# Altium schematic sheet oled-display-v3.2.SchDoc(oled-display-v3.2)
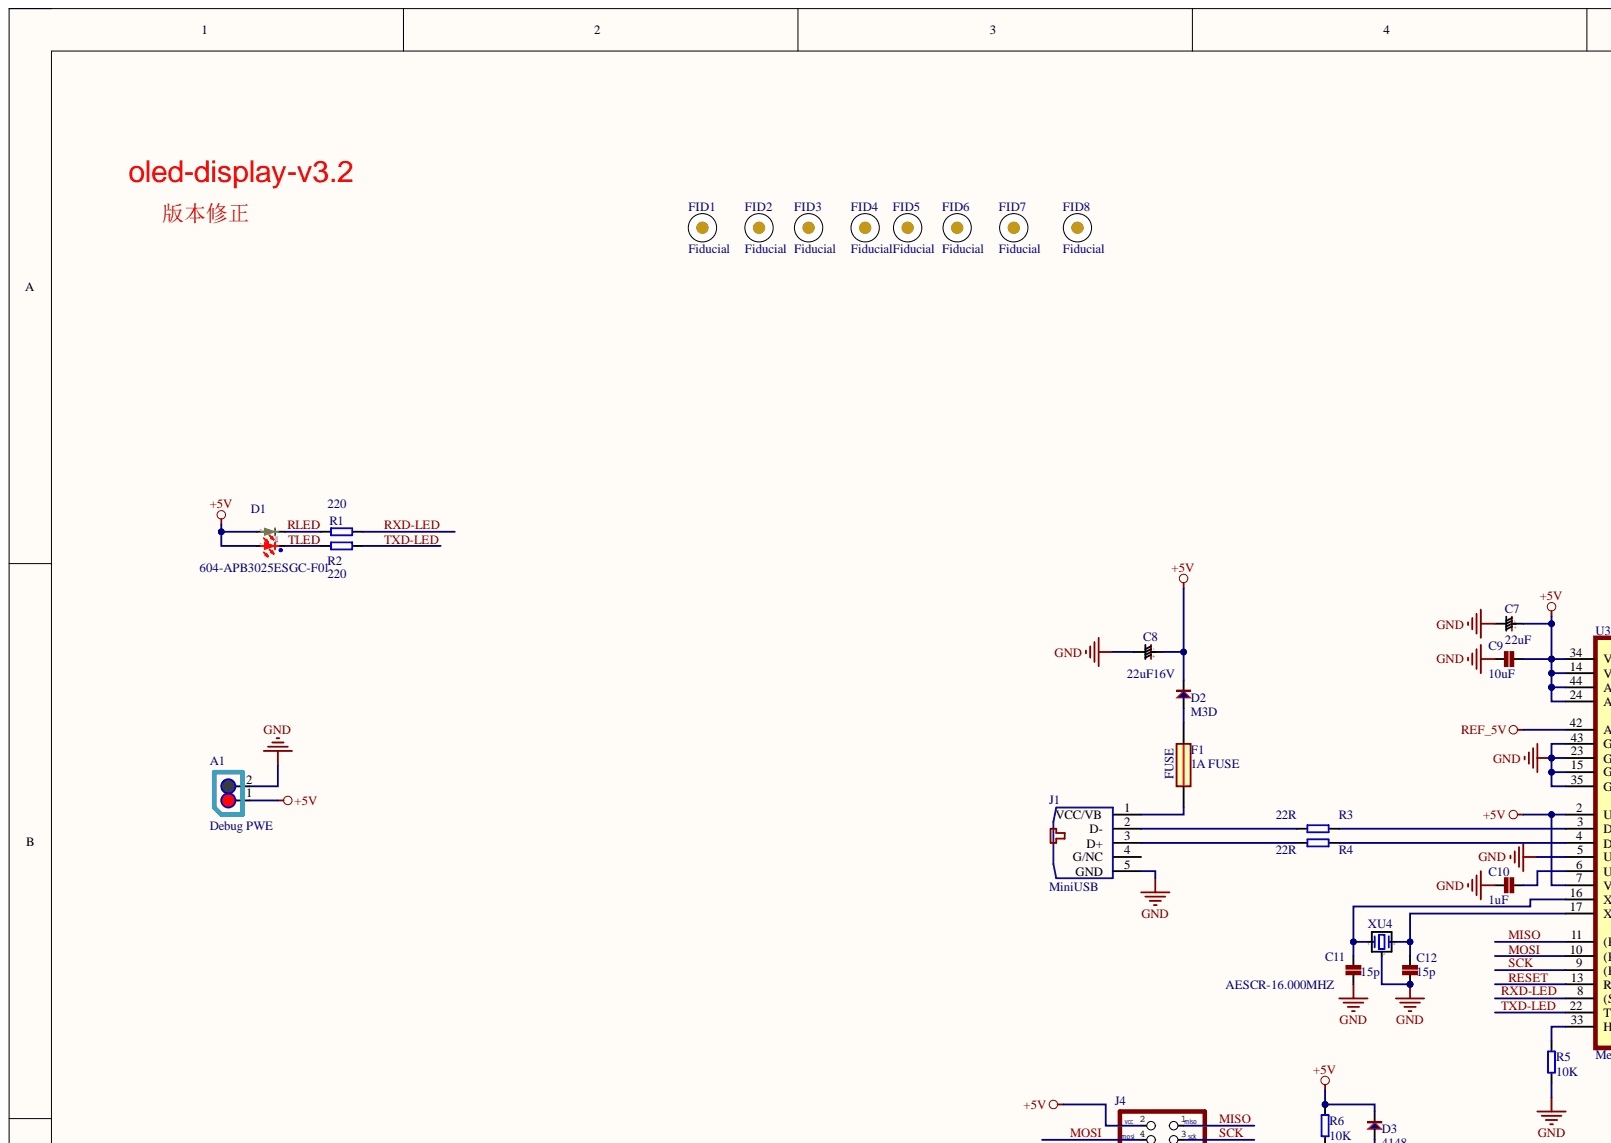
[diagram p0: oled-display-v3.2.SchDoc, top left]
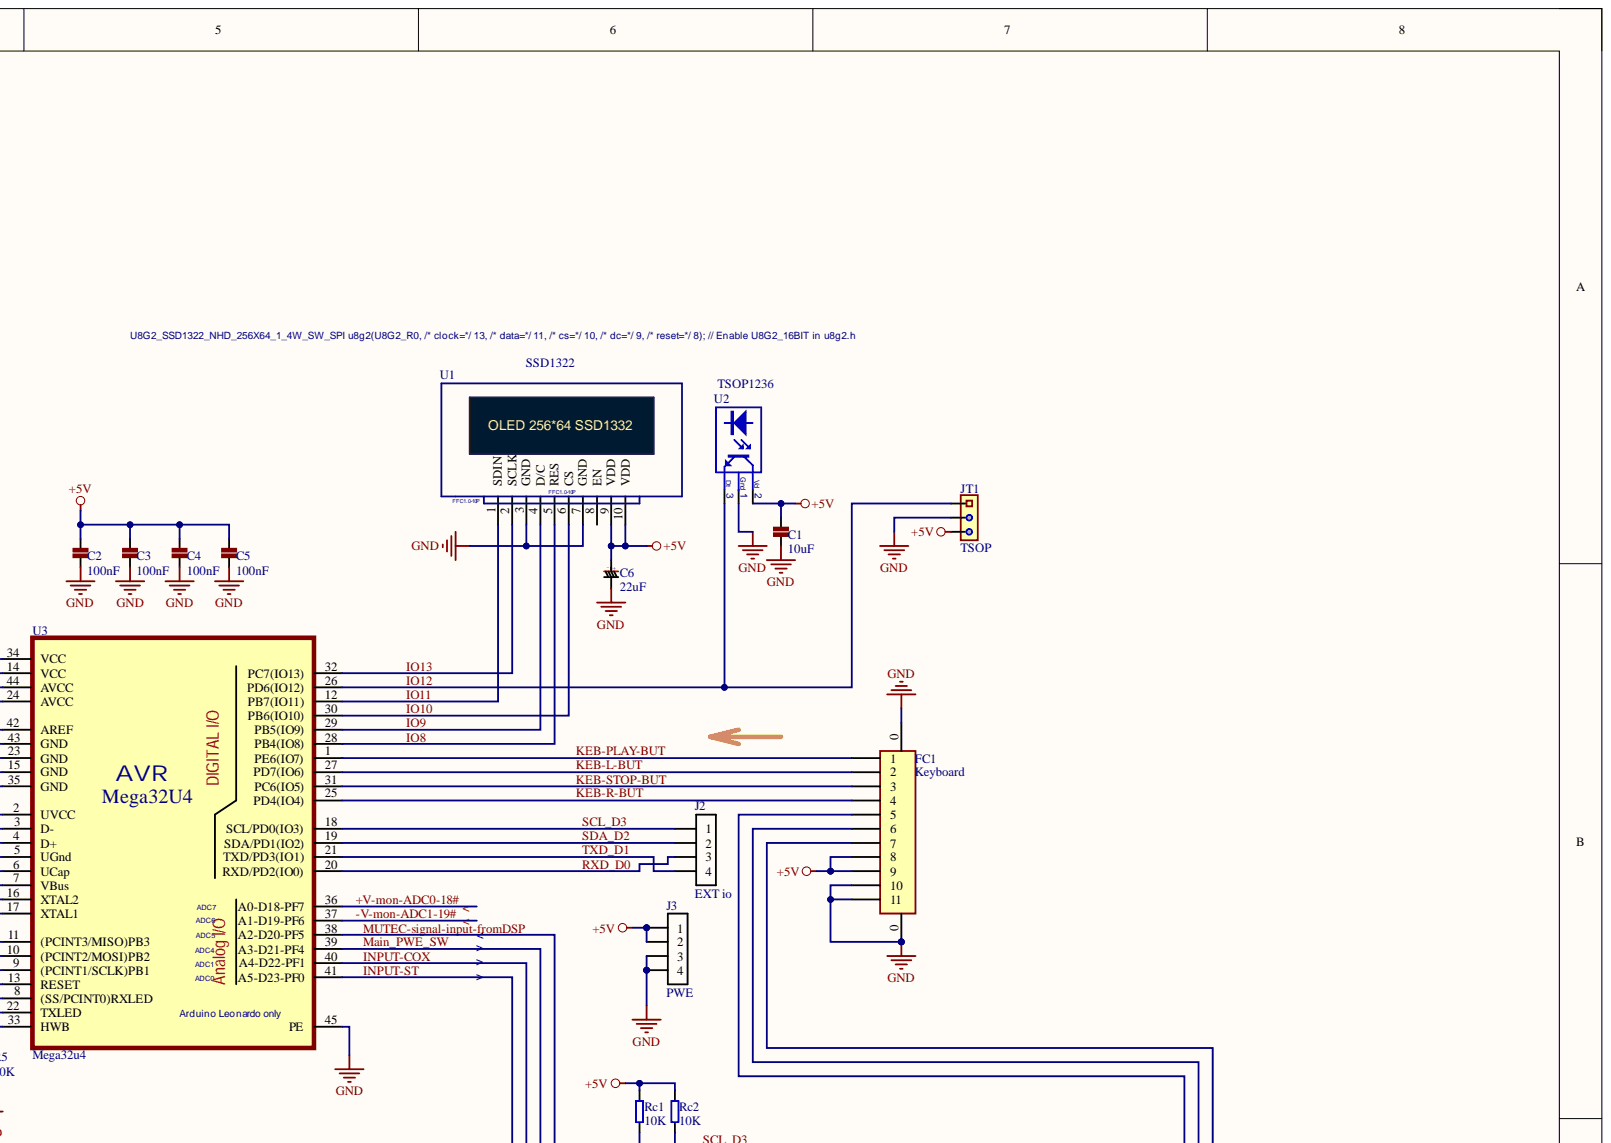
[diagram p1: oled-display-v3.2.SchDoc, top right]
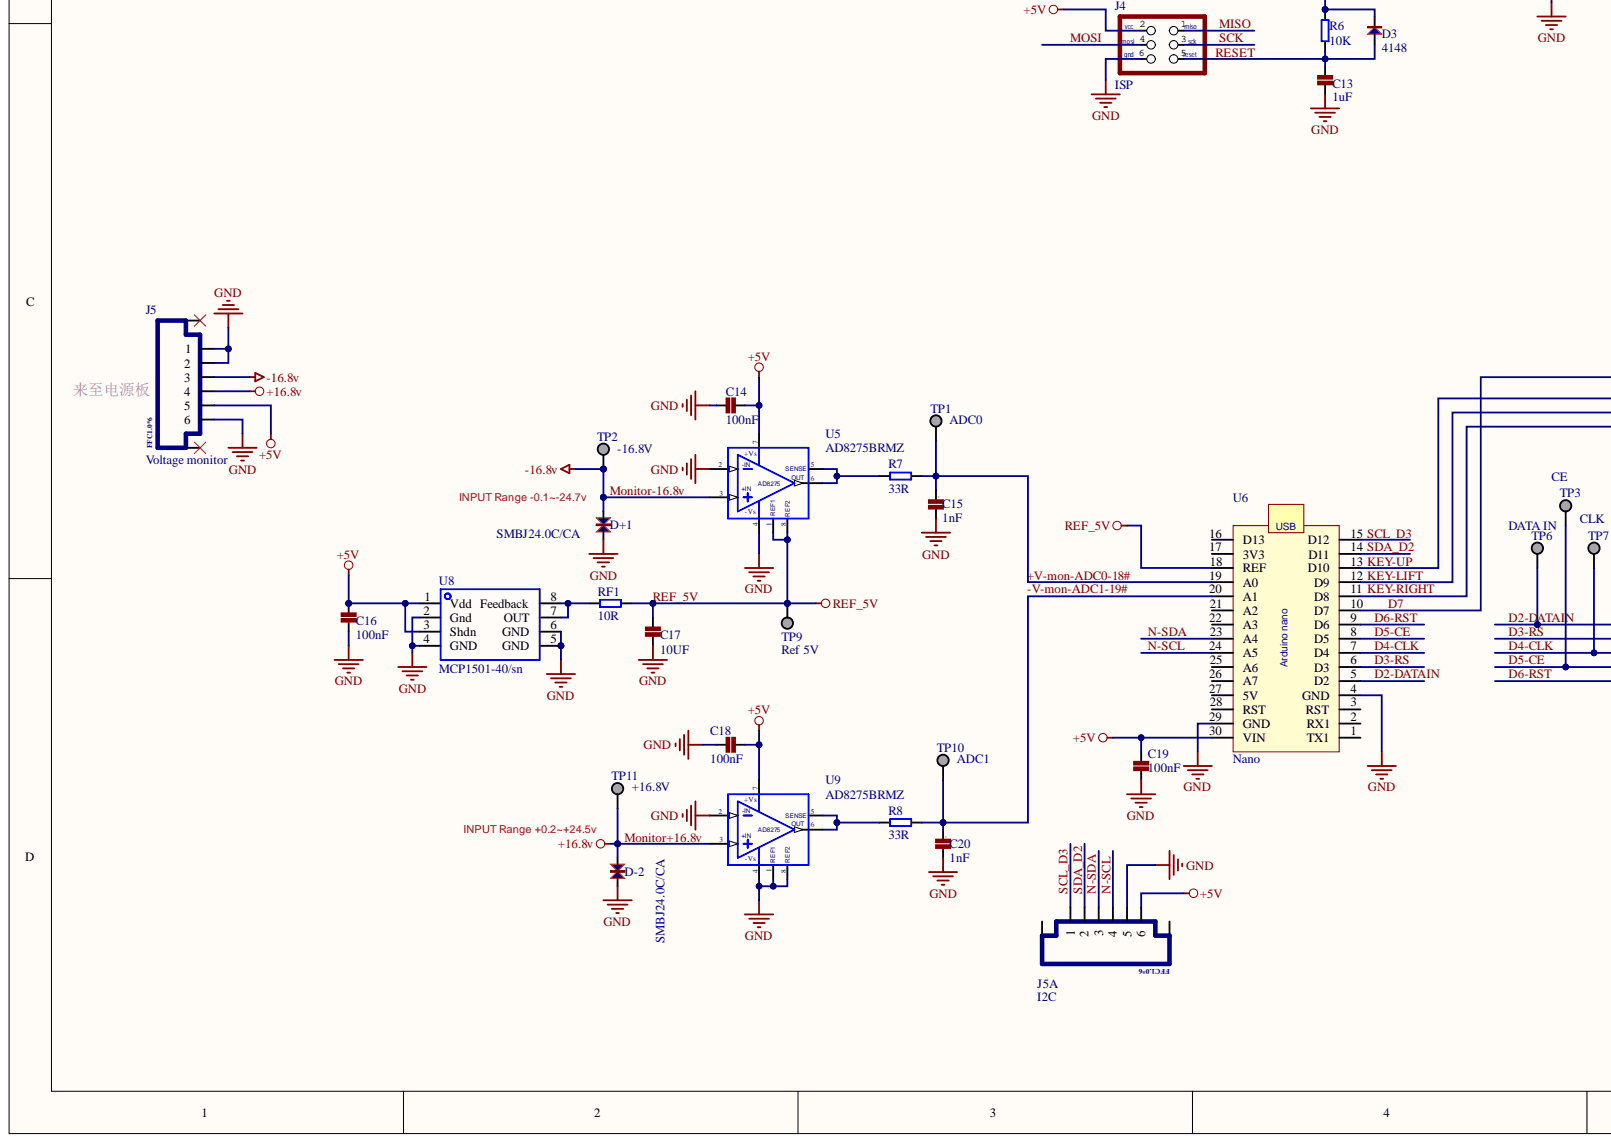
[diagram p2: oled-display-v3.2.SchDoc, bottom left]
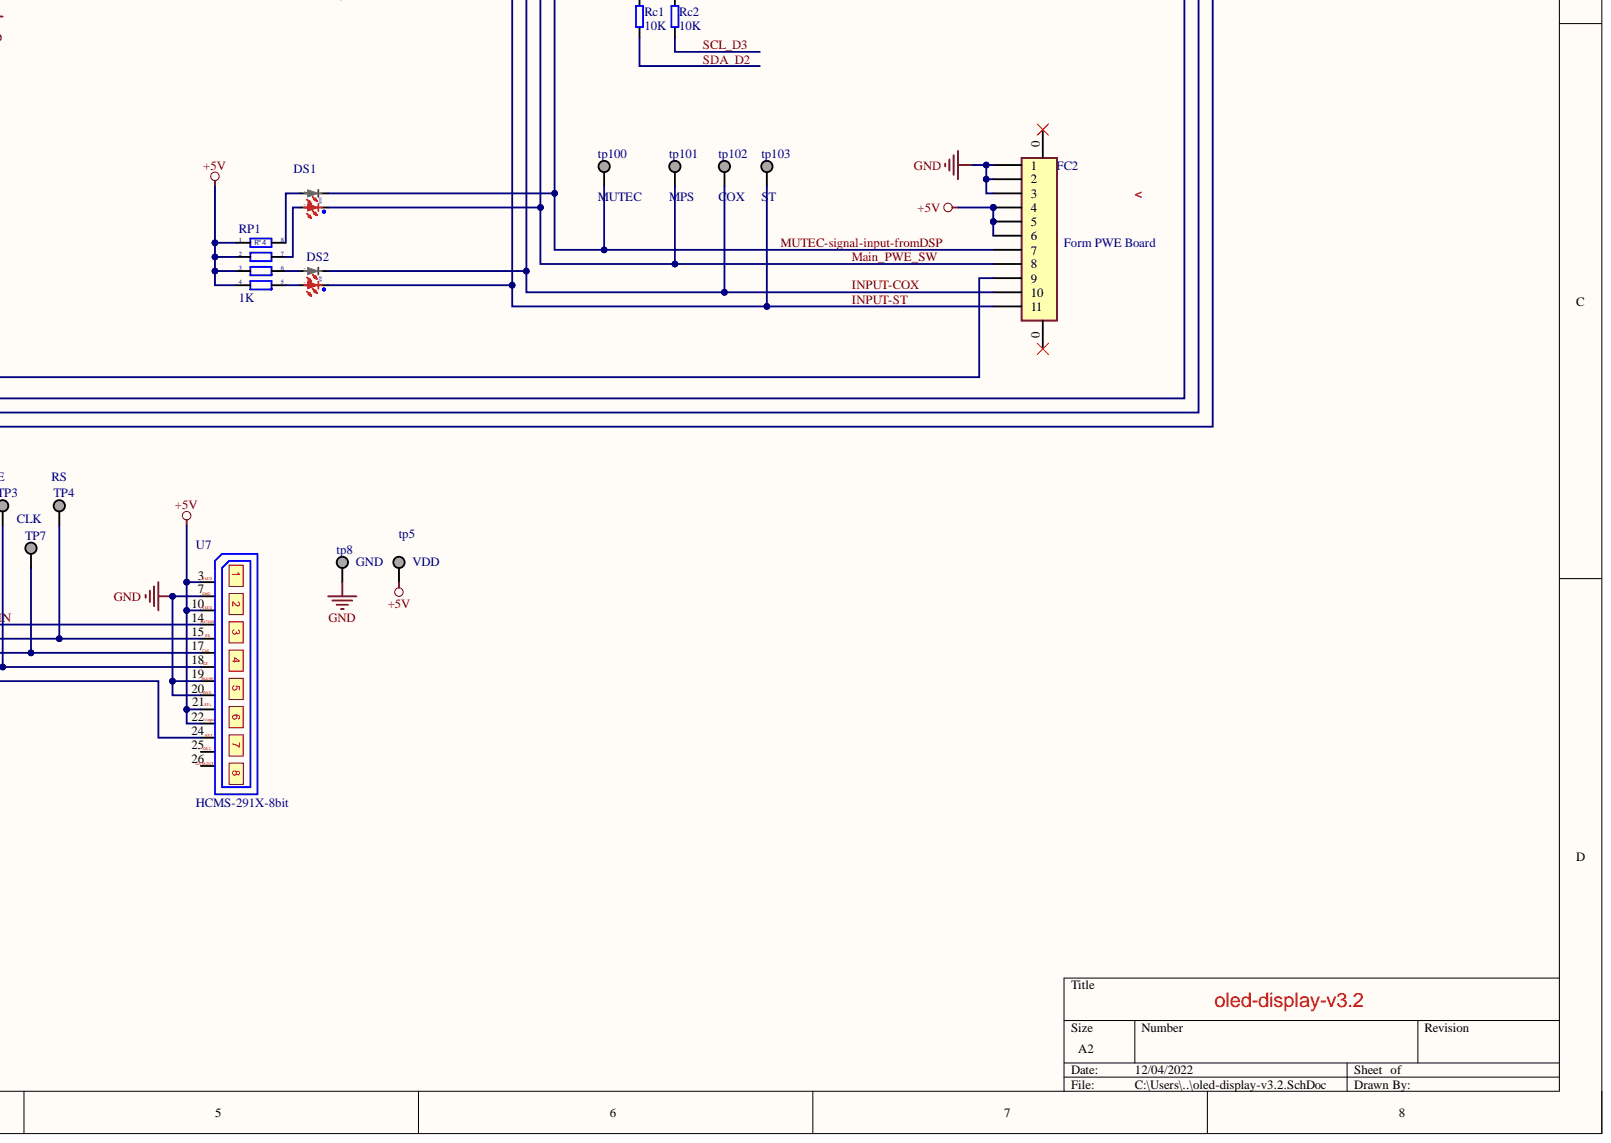
[diagram p3: oled-display-v3.2.SchDoc, bottom right]

## Parts
A1  Debug PWE  [PH2.0-2P]
C1  10uF  [C0603]
C2  100nF  [C0603]
C3  100nF  [C0603]
C4  100nF  [C0603]
C5  100nF  [C0603]
C6  22uF  [1210]
C7  22uF  [1206]
C8  22uF16V  [1210]
C9  10uF  [C0603]
C10  1uF  [c0805]
C11  15p  [C0603]
C12  15p  [C0603]
C13  1uF  [C0603]
C14  100nF  [C0603]
C15  1nF  [C0603]
C16  100nF  [C0603]
C17  10UF  [c0805]
C18  100nF  [C0603]
C19  100nF  [C0603]
C20  1nF  [C0603]
D1  604-APB3025ESGC-F01  [3825]
D2  M3D  [SMA [DO-214AC]]
D3  4148  [1206-4148]
D+1  SMBJ24.0C/CA  [SMA [DO-214AC]]
D-2  SMBJ24.0C/CA  [SMA [DO-214AC]]
DS1  604-APB3025ESGC-F01  [3825]
DS2  604-APB3025ESGC-F01  [3825]
F1  1A FUSE  [1206-FUSE]
FC1  Keyboard  [FFC1.0-11P-2]
FC2  Form PWE Board  [FFC1.0-11P-2]
FID1  Fiducial  [FID]
FID2  Fiducial  [FID]
FID3  Fiducial  [FID]
FID4  Fiducial  [FID]
FID5  Fiducial  [FID]
FID6  Fiducial  [FID]
FID7  Fiducial  [FID]
FID8  Fiducial  [FID]
J1  MiniUSB  [miniusb180deg]
J2  EXT io  [sip4]
J3  PWE  [sip4]
J4  ISP  [SIP6]
J5  Voltage monitor  [PFC6]
J5A  I2C  [PFC6]
JT1  TSOP  [SIP3]
R1  220  [R0603]
R2  220  [R0603]
R3  22R  [R0603]
R4  22R  [R0603]
R5  10K  [R0603]
R6  10K  [R0603]
R7  33R  [R0603]
R8  33R  [R0603]
Rc1  10K  [R0603]
Rc2  10K  [R0603]
RF1  10R  [R0603]
RP1  1K  [PR0603]
TP1  ADC0  [TP]
TP2  -16.8V  [TP]
TP3  CE  [TP]
TP4  RS  [TP]
tp5  VDD  [tps]
TP6  DATA IN  [TP]
TP7  CLK  [TP]
tp8  GND  [tps]
TP9  Ref 5V  [TP]
TP10  ADC1  [TP]
TP11  +16.8V  [TP]
tp100  MUTEC  [TP]
tp101  MPS  [TP]
tp102  COX  [TP]
tp103  ST  [TP]
U1  SSD1322  [OLED256*64]
U2  TSOP1236  [TSOP1236]
U3  Mega32u4  [QFN44ML7X7]
U5  AD8275BRMZ  [MSO8]
U6  Nano  [arduino nano]
U7  HCMS-291X-8bit  [HCMS-8bit-DIP26]
U8  MCP1501-40/sn  [SO8]
U9  AD8275BRMZ  [MSO8]
XU4  AESCR-16.000MHZ  [3.2*1.3*1.0]

## Nets
+5V: A1.1 C1.1 C2.1 C3.1 C4.1 C5.1 C6.1 C7.1 C8.1 C9.1 C14.2 C16.1 C18.1 C19.2 D1.2 D1.4 D2.1 D3.1 FC1.8 FC1.9 FC2.4 FC2.5 FC2.6 J3.1 J3.2 J4.2 J5.5 J5A.6 JT1.3 R6.1 Rc1.1 Rc2.1 RP1.1 RP1.2 RP1.3 RP1.4 tp5.1 U1.9(VDD) U1.10(VDD) U2.2 U3.2(UVCC) U3.7(VBus) U3.14(VCC) U3.24(AVCC) U3.34(VCC) U3.44(AVCC) U5.7 U6.30(VIN) U7.3 U7.10 U7.21 U7.22 U8.1(Vdd) U8.3(Shdn) U9.7
GND: A1.2 C1.2 C2.2 C3.2 C4.2 C5.2 C6.2 C7.2 C8.2 C9.2 C10.2 C11.1 C12.1 C13.2 C14.1 C15.2 C16.2 C17.2 C18.2 C19.1 C20.2 D+1.2 D-2.2 FC1.0 FC1.10 FC1.11 FC2.1 FC2.2 FC2.3 J1.5(GND) J3.3 J3.4 J4.6 J5.1 J5.2 J5.6 J5A.5 JT1.2 R5.2 tp8.1 U1.3(GND) U1.7(GND) U2.1 U3.5(UGnd) U3.15(GND) U3.23(GND) U3.35(GND) U3.43(GND) U3.45(PE) U5.2(-IN) U5.4 U6.4(GND) U6.29(GND) U7.7 U7.19 U7.20 U8.2(Gnd) U8.4(GND) U8.5(GND) U8.6(GND) U9.1(REF1) U9.2 U9.4 U9.8(REF2) XU4.2
+V-mon-ADC0-18#: C15.1 R7.2 TP1.1 U3.36(A0-D18-PF7) U6.19(A0)
-V-mon-ADC1-19#: C20.1 R8.2 TP10.1 U3.37(A1-D19-PF6) U6.20(A1)
D2-DATAIN: TP6.1 U6.5(D2) U7.14
D3-RS: TP4.1 U6.6(D3) U7.15
D4-CLK: TP7.1 U6.7(D4) U7.17
D5-CE: TP3.1 U6.8(D5) U7.18
D6-RST: U6.9(D6) U7.24
D7: FC2.9 U6.10(D7)
INPUT-COX: DS2.1 FC2.10 tp102.1 U3.40(A4-D22-PF1)
INPUT-ST: DS2.3 FC2.11 tp103.1 U3.41(A5-D23-PF0)
IO8: U1.5(RES) U3.28(PB4(IO8))
IO9: U1.4(D/C) U3.29(PB5(IO9))
IO10: U1.6(CS) U3.30(PB6(IO10))
IO11: U1.1(SDIN) U3.12(PB7(IO11))
IO12: JT1.1 U2.3 U3.26(PD6(IO12))
IO13: U1.2(SCLK) U3.32(PC7(IO13))
KEB-L-BUT: FC1.2 U3.27(PD7(IO6))
KEB-PLAY-BUT: FC1.1 U3.1(PE6(IO7))
KEB-R-BUT: FC1.4 U3.25(PD4(IO4))
KEB-STOP-BUT: FC1.3 U3.31(PC6(IO5))
KEY-LIFT: FC1.6 U6.12(D9)
KEY-RIGHT: FC1.7 U6.11(D8)
KEY-UP: FC1.5 U6.13(D10)
Main_PWE_SW: DS1.3 FC2.8 tp101.1 U3.39(A3-D21-PF4)
MISO: J4.1 U3.11((PCINT3/MISO)PB3)
Monitor+16.8v: D-2.1 J5.4 TP11.1 U9.3
Monitor-16.8v: D+1.1 J5.3 TP2.1 U5.3
MOSI: J4.4 U3.10((PCINT2/MOSI)PB2)
MUTEC-signal-input-fromDSP: DS1.1 FC2.7 tp100.1 U3.38(A2-D20-PF5)
N-SCL: J5A.4 U6.24(A5)
N-SDA: J5A.3 U6.23(A4)
REF_5V: C17.1 RF1.2 TP9.1 U3.42(AREF) U5.1(REF1) U5.8(REF2) U6.18(REF)
RESET: C13.1 D3.2 J4.5 R6.2 U3.13(RESET)
RLED: D1.1 R1.1
RXD-LED: R1.2 U3.8((SS/PCINT0)RXLED)
RXD_D0: J2.3 U3.20(RXD/PD2(IO0))
SCK: J4.3 U3.9((PCINT1/SCLK)PB1)
SCL_D3: J2.1 J5A.1 Rc2.2 U3.18(SCL/PD0(IO3)) U6.15(D12)
SDA_D2: J2.2 J5A.2 Rc1.2 U3.19(SDA/PD1(IO2)) U6.14(D11)
TLED: D1.3 R2.1
TXD-LED: R2.2 U3.22(TXLED)
TXD_D1: J2.4 U3.21(TXD/PD3(IO1))
NetC10_1: C10.1 U3.6(UCap)
NetC11_2: C11.2 U3.16(XTAL2) XU4.1
NetC12_2: C12.2 U3.17(XTAL1) XU4.3
NetD2_2: D2.2 F1.2
NetDS1_2: DS1.2 RP1.8(R*4)
NetDS1_4: DS1.4 RP1.7
NetDS2_2: DS2.2 RP1.6
NetDS2_4: DS2.4 RP1.5
NetF1_1: F1.1 J1.1(VCC/VB)
NetJ1_2: J1.2(D-) R3.1
NetJ1_3: J1.3(D+) R4.1
NetR3_2: R3.2 U3.3(D-)
NetR4_2: R4.2 U3.4(D+)
NetR5_1: R5.1 U3.33(HWB)
NetR7_1: R7.1 U5.5(SENSE) U5.6(AD8275)
NetR8_1: R8.1 U9.5(SENSE) U9.6(AD8275)
NetRF1_1: RF1.1 U8.7(OUT) U8.8(Feedback)

Not connected: FC2 0; J1 4(G/NC); J5 0; J5A 0; U1 8(EN); U6 1(TX1) 2(RX1) 3(RST) 16(D13) 17(3V3) 21(A2) 22(A3) 25(A6) 26(A7) 27(5V) 28(RST); U7 25 26

## Library data of the parts
A1 = XH-2P: XH2.54  L7.5*D4.9 H5.69; Component Kind: Standard; Library Name: 2017-1.SCHLIB
C1-C5 C9-C20 = Cap: Capactitor; Component Kind: Standard; Library Name: 2017-1.SCHLIB
C6-C8 = Cape: Electrdytic Capactitor; Component Kind: Standard; Library Name: 2017-1.SCHLIB
D1 DS1 DS2 = RG-LED35281210: Component Kind: Standard; Library Name: 2017-1.SCHLIB
D2 D3 = Diodes: Component Kind: Standard; Library Name: 2017-1.SCHLIB
D+1 D-2 = TVS-BI: Bi-directional 双向不通; Component Kind: Standard; Library Name: 2017-1.SCHLIB
F1 = FUSE: Component Kind: Standard; Library Name: 2017-1.SCHLIB
FC1 FC2 = FFC1.0-11P: Component Kind: Standard; Library Name: 2017-1.SCHLIB
FID1-FID8 = FID: Fiducial mark.  There is nothing to buy or mount. Fiducial; Component Kind: Standard; Library Name: 2017-1.SCHLIB
J1 = MiniUSB: WM17116CT-ND  RS515-2033; Component Kind: Standard; Library Name: 2017-1.SCHLIB
J2 J3 = SIP3-1.27: Component Kind: Standard; Library Name: 2017-1.SCHLIB
J4 = ICSP: Component Kind: Standard; Library Name: 2017-1.SCHLIB
J5 J5A = FFC1.0*6P: Component Kind: Standard; Library Name: 2017-1.SCHLIB
JT1 = SIP3: Component Kind: Standard; Library Name: 2017-1.SCHLIB
R1-R8 Rc1 Rc2 RF1 = Resistor: Component Kind: Standard; Library Name: 2017-1.SCHLIB
RP1 = RP4x4p: Component Kind: Standard; Library Name: 2017-1.SCHLIB
TP1-TP4 tp5 TP6 TP7 tp8 TP9-TP11 tp100-tp103 = Tp: Component Kind: Standard; Library Name: 2017-1.SCHLIB
U1 = OLED3.1-256*64: 3.1 256*64 OLED; Component Kind: Standard; Library Name: 2017-1.SCHLIB
U2 = TSOP1236: IR remeto chip; Component Kind: Standard; Library Name: 2017-1.SCHLIB
U5 U9 = AD8275BRMZ: G=0.2 ADC Driver; Component Kind: Standard; Library Name: 2017-1.SCHLIB
U6 = Arduino Nano: Component Kind: Standard; Library Name: 2017-1.SCHLIB
U7 = HCMS-291X-8bit: 8bit display; Component Kind: Standard; Library Name: 2017-1.SCHLIB
U8 = MCP1501-10E: Component Kind: Standard; Library Name: 2017-1.SCHLIB
XU4 = Crystor-AWSCR: Component Kind: Standard; Library Name: 2017-1.SCHLIB
U3 = Mega32u4: Component Kind: Standard; Library Name: 2017-1.SCHLIB
